# Altium schematic sheet Brightside_Telemetry_stm_rev2.SchDoc
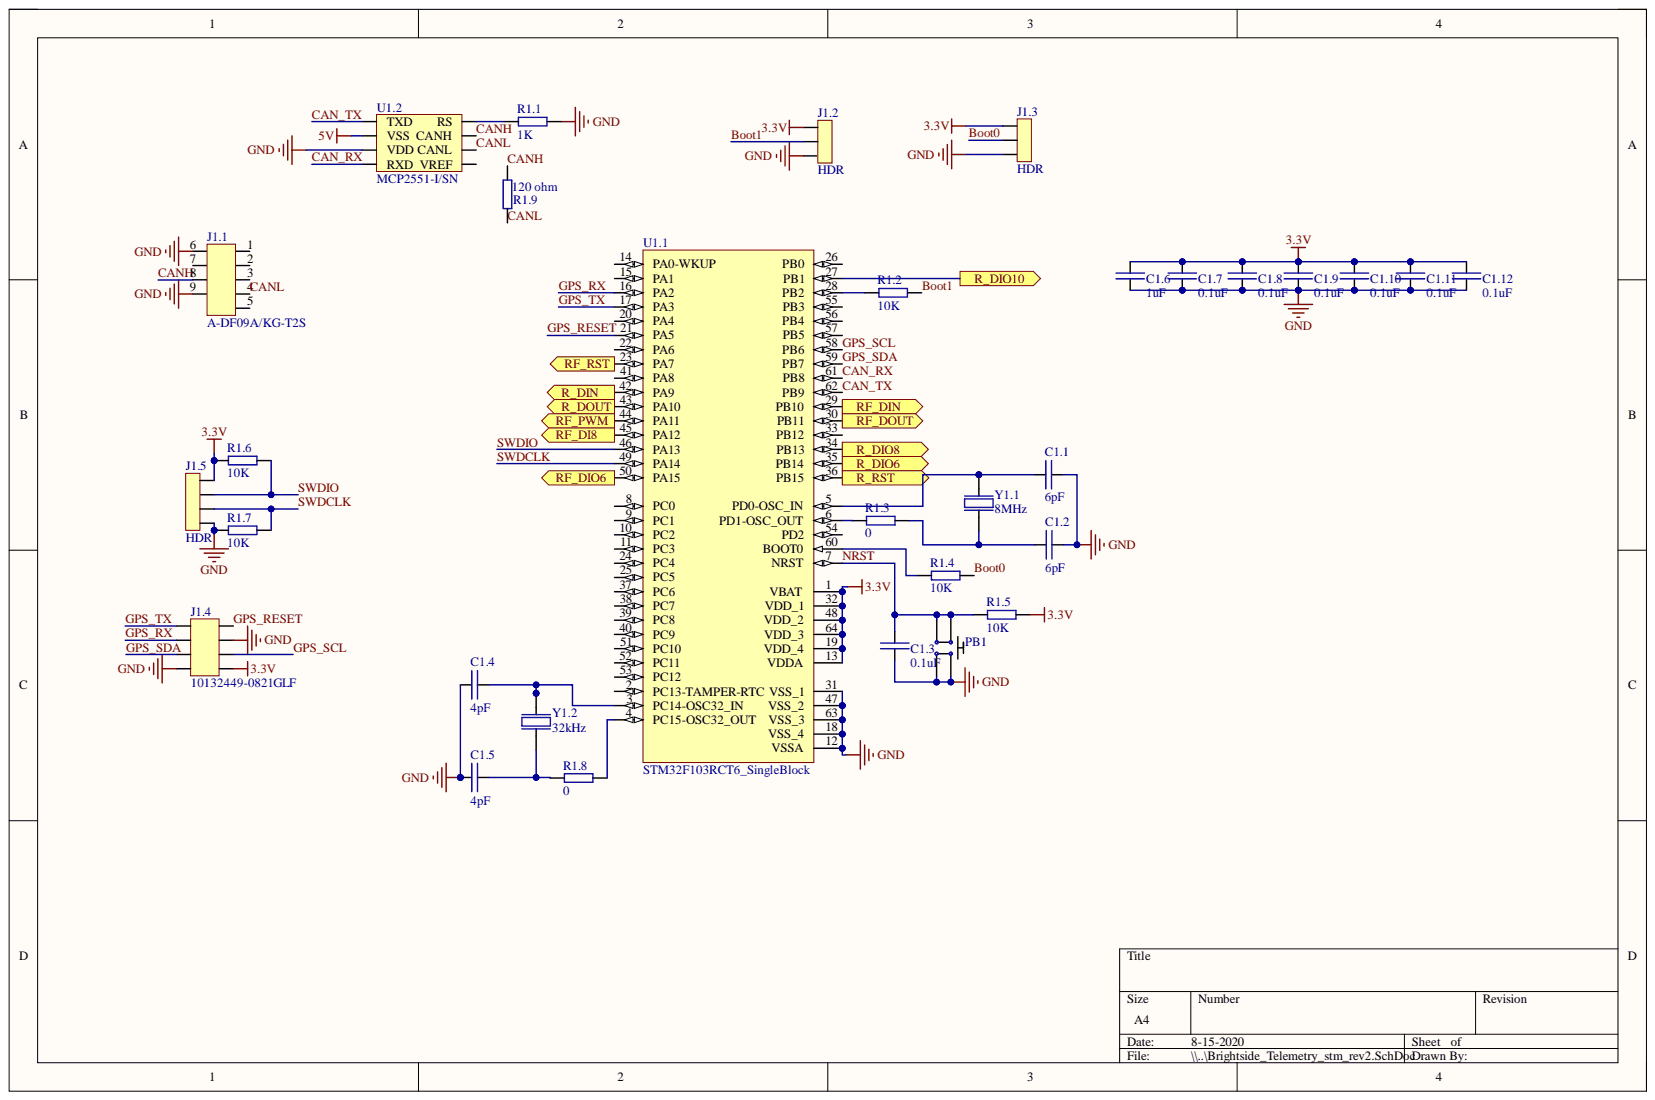
[diagram p0: Brightside_Telemetry_stm_rev2.SchDoc]

## Parts
C1.1  6pF  [0603]
C1.2  6pF  [0603]
C1.3  0.1uF  [C0805]
C1.4  4pF  [0603]
C1.5  4pF  [0603]
C1.6  1uF  [1206]
C1.7  0.1uF  [C0805]
C1.8  0.1uF  [C0805]
C1.9  0.1uF  [C0805]
C1.10  0.1uF  [C0805]
C1.11  0.1uF  [C0805]
C1.12  0.1uF  [C0805]
J1.1  A-DF09A/KG-T2S  [DB9 (Female)]
J1.2  HDR  [0.1" Header 3pos]
J1.3  HDR  [0.1" Header 3pos]
J1.4  10132449-0821GLF  [Micro-Fit 3.0 8pos]
J1.5  HDR  [0.1" Header 4pos]
PB1  P12961SCT-ND  [P12961SCT-ND]  (value not drawn)
R1.1  1K  [R0805]
R1.2  10K  [R0805]
R1.3  0  [0805]
R1.4  10K  [R0805]
R1.5  10K  [R0805]
R1.6  10K  [R0805]
R1.7  10K  [R0805]
R1.8  0  [0805]
R1.9  120 ohm  [R0805]
U1.1  STM32F103RCT6_SingleBlock  [64-LQFP]
U1.2  MCP2551-I/SN  Operating Supply Voltage: 5V  [8-SOIC]
Y1.1  8MHz  [NX8045GB-8.000000MHZ]
Y1.2  32kHz  [FC-135 32.7680KA-AG]

## Ports
R_DIN, R_DIO6, R_DIO8, R_DIO10, R_DOUT, R_RST, RF_DI8, RF_DIN, RF_DIO6, RF_DOUT, RF_PWM, RF_RST

## Nets
3.3V: C1.6.1 C1.7.2 C1.8.2 C1.9.2 C1.10.2 C1.11.2 C1.12.2 J1.2.1 J1.3.1 J1.4.4 J1.5.4 R1.5.2 R1.6.1 U1.1.1(VBAT) U1.1.13(VDDA) U1.1.19(VDD_4) U1.1.32(VDD_1) U1.1.48(VDD_2) U1.1.64(VDD_3)
5V: U1.2.2(VSS)
GND: C1.1.2 C1.2.2 C1.3.1 C1.4.1 C1.5.1 C1.6.2 C1.7.1 C1.8.1 C1.9.1 C1.10.1 C1.11.1 C1.12.1 J1.1.6 J1.1.9 J1.2.3 J1.3.3 J1.4.2 J1.4.8 J1.5.1 PB1.2 PB1.4 R1.1.2 R1.7.1 U1.1.12(VSSA) U1.1.18(VSS_4) U1.1.31(VSS_1) U1.1.47(VSS_2) U1.1.63(VSS_3) U1.2.3(VDD)
Boot0: J1.3.2 R1.4.2
Boot1: J1.2.2 R1.2.2
CAN_RX: U1.1.61(PB8) U1.2.4(RXD)
CAN_TX: U1.1.62(PB9) U1.2.1(TXD)
CANH: J1.1.8 R1.9.2 U1.2.7(CANH)
CANL: J1.1.4 R1.9.1 U1.2.6(CANL)
GPS_RESET: J1.4.1 U1.1.21(PA5)
GPS_RX: J1.4.6 U1.1.16(PA2)
GPS_SCL: J1.4.3 U1.1.58(PB6)
GPS_SDA: J1.4.7 U1.1.59(PB7)
GPS_TX: J1.4.5 U1.1.17(PA3)
NRST: C1.3.2 PB1.1 PB1.3 R1.5.1 U1.1.7(NRST)
R_DIN: U1.1.42(PA9) ; port R_DIN
R_DIO6: U1.1.35(PB14) ; port R_DIO6
R_DIO8: U1.1.34(PB13) ; port R_DIO8
R_DIO10: U1.1.27(PB1) ; port R_DIO10
R_DOUT: U1.1.43(PA10) ; port R_DOUT
R_RST: U1.1.36(PB15) ; port R_RST
RF_DI8: U1.1.45(PA12) ; port RF_DI8
RF_DIN: U1.1.29(PB10) ; port RF_DIN
RF_DIO6: U1.1.50(PA15) ; port RF_DIO6
RF_DOUT: U1.1.30(PB11) ; port RF_DOUT
RF_PWM: U1.1.44(PA11) ; port RF_PWM
RF_RST: U1.1.23(PA7) ; port RF_RST
SWDCLK: J1.5.2 R1.7.2 U1.1.49(PA14)
SWDIO: J1.5.3 R1.6.2 U1.1.46(PA13)
NetC1.1_1: C1.1.1 U1.1.5(PD0-OSC_IN) Y1.1.2
NetC1.2_1: C1.2.1 R1.3.2 Y1.1.1
NetC1.4_2: C1.4.2 U1.1.3(PC14-OSC32_IN) Y1.2.1
NetC1.5_2: C1.5.2 R1.8.1 Y1.2.2
NetR1.1_1: R1.1.1 U1.2.8(RS)
NetR1.2_1: R1.2.1 U1.1.28(PB2)
NetR1.3_1: R1.3.1 U1.1.6(PD1-OSC_OUT)
NetR1.4_1: R1.4.1 U1.1.60(BOOT0)
NetR1.8_2: R1.8.2 U1.1.4(PC15-OSC32_OUT)

Not connected: J1.1 1 2 3 5 7; U1.1 2(PC13-TAMPER-RTC) 8(PC0) 9(PC1) 10(PC2) 11(PC3) 14(PA0-WKUP) 15(PA1) 20(PA4) 22(PA6) 24(PC4) 25(PC5) 26(PB0) 33(PB12) 37(PC6) 38(PC7) 39(PC8) 40(PC9) 41(PA8) 51(PC10) 52(PC11) 53(PC12) 54(PD2) 55(PB3) 56(PB4) 57(PB5); U1.2 5(VREF)

## Library data of the parts
C1.1 C1.2 = CC0603DRNPO9BN6R0: CAP CER 6PF 50V C0G/NPO 0603; Manufacturer: Yageo; Dielectric: C0G; Capacitance: 6pF; Case Code (Imperial): 0603; Case Code (Metric): 1608; Case/Package: 0603; Category: Ceramic Capacitors; Component Kind: Standard; ComponentLink1Description: https://datasheet.ciiva.com/145/mlccorderingcode-145158.pdf?src-supplier=Digi-Key; ComponentLink1URL: https://datasheet.ciiva.com/145/mlccorderingcode-145158.pdf?src-supplier=Digi-Key; ComponentLink2Description: https://datasheet.ciiva.com/145/mlccorderingcode-145159.pdf?src-supplier=Digi-Key; ComponentLink2URL: https://datasheet.ciiva.com/145/mlccorderingcode-145159.pdf?src-supplier=Digi-Key; ComponentLink3Description: https://datasheet.ciiva.com/145/mlccorderingcode-145160.pdf?src-supplier=Digi-Key; ComponentLink3URL: https://datasheet.ciiva.com/145/mlccorderingcode-145160.pdf?src-supplier=Digi-Key; Composition: Ceramic; Depth: 0.8mm; Lead Free: Lead Free; Length: 1.6mm; Library Name: Capacitors.SchLib; Manufacturer Part Number: CC0603DRNPO9BN6R0; Max Operating Temperature: 125°C; Min Operating Temperature: -55°C; Mount: Surface Mount; Package Quantity: 1; Packaging: Tape and Reel; RoHS: Yes; Stock 1: 25117; Supplier 1: Digi-Key; Supplier Part Number 1: 311-1055-1-ND; Termination: SMD/SMT; Thickness: 0.035inch; Voltage Rating: 50V; Voltage Rating (DC): 50V; Weight: 7.1E-05oz; Width: 0.8mm
C1.3 C1.7-C1.12 = CL21B104KBCWPNC: CAP CER 0.1UF 50V X7R 0805; Manufacturer: Samsung; Capacitance: 100nF; Tolerance: 10%; Case Code (Imperial): 0805; Case Code (Metric): 2012; Case/Package: 0805; Category: Capacitors; Component Kind: Standard; Features: Soft Termination; Length: 0.079inch; Library Name: Capacitors.SchLib; Manufacturer Part Number: CL21B104KBCWPNC; Max Operating Temperature: 125°C; Min Operating Temperature: -55°C; Mount: Surface Mount; Packaging: Tape and Reel; Pricing 1: 1 = 0.10000, 10 = 0.06700, 100 = 0.02990, 500 = 0.02138, 1000 = 0.01680 (USD); Ratings: AEC-Q200; RoHS: Yes; Stock 1: 6545; Supplier 1: Digi-Key; Supplier Part Number 1: 1276-6557-1-ND; Thickness: 0.053inch; Voltage Rating: 50V; Width: 0.049inch
C1.4 C1.5 = CC0603CRNPO9BN4R0: CAP CER 4PF 50V C0G/NPO 0603; Manufacturer: Yageo; Dielectric: C0G; Insulation Resistance: 10GR; Capacitance: 4pF; Case Code (Imperial): 0603; Case Code (Metric): 1608; Case/Package: 0603; Category: Ceramic Capacitors; Component Kind: Standard; ComponentLink1Description: https://datasheet.ciiva.com/145/mlccorderingcode-145161.pdf?src-supplier=Digi-Key; ComponentLink1URL: https://datasheet.ciiva.com/145/mlccorderingcode-145161.pdf?src-supplier=Digi-Key; ComponentLink2Description: https://datasheet.ciiva.com/145/mlccorderingcode-145162.pdf?src-supplier=Digi-Key; ComponentLink2URL: https://datasheet.ciiva.com/145/mlccorderingcode-145162.pdf?src-supplier=Digi-Key; ComponentLink3Description: https://datasheet.ciiva.com/145/mlccorderingcode-145163.pdf?src-supplier=Digi-Key; ComponentLink3URL: https://datasheet.ciiva.com/145/mlccorderingcode-145163.pdf?src-supplier=Digi-Key; Composition: Ceramic; Depth: 0.8mm; Lead Free: Lead Free; Length: 1.6mm; Library Name: Capacitors.SchLib; Manufacturer Part Number: CC0603CRNPO9BN4R0; Max Operating Temperature: 125°C; Min Operating Temperature: -55°C; Mount: Surface Mount; Number of Pins: 2; Package Quantity: 1; Packaging: Tape and Reel; RoHS: Yes; Stock 1: 1954; Supplier 1: Digi-Key; Supplier Part Number 1: 311-1053-1-ND; Termination: SMD/SMT; Thickness: 0.035inch; Voltage Rating: 50V; Voltage Rating (DC): 50V; Weight: 7.1E-05oz; Width: 0.8mm
C1.6 = 885012208036: CAP CER 1UF 16V X7R 1206; Manufacturer: Wurth Electronics; Tolerance: 10%; Capacitance: 1uF; Case Code (Imperial): 1206; Case Code (Metric): 3216; Case/Package: 1206; Category: Ceramic Capacitors; Component Kind: Standard; ComponentLink1Description: http://katalog.we-online.de/pbs/datasheet/885012208036.pdf?src-supplier=Digi-Key; ComponentLink1URL: http://katalog.we-online.de/pbs/datasheet/885012208036.pdf?src-supplier=Digi-Key; ComponentLink2Description: https://katalog.we-online.de/pbs/datasheet/885012208036.pdf?src-supplier=Digi-Key; ComponentLink2URL: https://katalog.we-online.de/pbs/datasheet/885012208036.pdf?src-supplier=Digi-Key; Length: 0.126inch; Library Name: Capacitors.SchLib; Manufacturer Part Number: 885012208036; Max Operating Temperature: 125°C; Min Operating Temperature: -55°C; Mount: Surface Mount; Packaging: Tape and Reel; RoHS: Yes; Stock 1: 2993; Supplier 1: Digi-Key; Supplier Part Number 1: 732-7692-1-ND; Thickness: 0.051inch; Voltage Rating: 16V; Width: 0.063inch
J1.1 = A-DF09A/KG-T2S: FEMALE DB9 D-SUBMINIATURE CONNECTOR, CURRENT RATING 3A, VOLTAGE RATING 250VAC; Manufacturer: Assmann; Connector Type: D-Sub,Receptacle,Signal; Max Supply Voltage (AC): 250V; Number of Ports: 1; Number of Positions: 9; Category: D-Sub Connectors; Color: Black; Component Kind: Standard; ComponentLink1Description: https://datasheet.ciiva.com/26927/getdatasheetpartid-755627-26927441.pdf?src-supplier=Verical; ComponentLink1URL: https://datasheet.ciiva.com/26927/getdatasheetpartid-755627-26927441.pdf?src-supplier=Verical; Contact Material: Brass; Contact Plating: Gold; Current Rating: 3A; Depth: 18.6mm; Housing Material: PBT; Lead Free: Lead Free; Length: 30.81mm; Library Name: Connectors.SchLib; Manufacturer Part Number: A-DF09A/KG-T2S; Max Operating Temperature: 125°C; Min Operating Temperature: -55°C; Mount: Through Hole; Number of Rows: 2; Number of Terminals: 9; Orientation: Right Angle; Package Quantity: 90; Packaging: Tray; Radiation Hardening: No; RoHS: Yes; Stock 1: 18258; Supplier 1: Digi-Key; Supplier Part Number 1: AE10921-ND; Termination: Solder
J1.2 J1.3 = 0.1" Header 3pos: Component Kind: Standard; Library Name: Connectors.SchLib
J1.4 = 10132449-0821GLF: Micro-fit 3.0 8pos right-angle; Manufacturer: Molex; Manufacturer Part Number: 43045-0800; Approvals: UL,CSA,TUV; Contact Resistance: 10mR; Current Rating: 5A; Gender: Male; Glow Wire Compliant: Compliant; Insulation Resistance: 1GR; Max Voltage Rating (DC): 250.25kV; Number of Circuits: 8; Pitch: 3mm; Voltage Rating: 600V; Voltage Rating (AC): 250V; Case/Package: SMC; Category: Headers and Wire Housings; Color: Black; Component Kind: Standard; ComponentLink1Description: https://datasheet.ciiva.com/5158/0430450800-pcb-headers-142625-5158817.pdf?src-supplier=Mouser; ComponentLink1URL: https://datasheet.ciiva.com/5158/0430450800-pcb-headers-142625-5158817.pdf?src-supplier=Mouser; ComponentLink2Description: https://datasheet.ciiva.com/7683/27645-7683683.pdf?src-supplier=Element14; ComponentLink2URL: https://datasheet.ciiva.com/7683/27645-7683683.pdf?src-supplier=Element14; ComponentLink3Description: https://datasheet.ciiva.com/11751/0900766b80027348-11751142.pdf?src-supplier=RSComponents; ComponentLink3URL: https://datasheet.ciiva.com/11751/0900766b80027348-11751142.pdf?src-supplier=RSComponents; ComponentLink4Description: https://datasheet.ciiva.com/30198/267770430450800-pcb-headers-30198875.pdf?src-supplier=Onlinecomponents.com; ComponentLink4URL: https://datasheet.ciiva.com/30198/267770430450800-pcb-headers-30198875.pdf?src-supplier=Onlinecomponents.com; ComponentLink5Description: https://datasheet.ciiva.com/19462/883536-19462164.pdf?src-supplier=Jameco; ComponentLink5URL: https://datasheet.ciiva.com/19462/883536-19462164.pdf?src-supplier=Jameco; ComponentLink6Description: https://datasheet.ciiva.com/14155/04402310-14155206.pdf?src-supplier=Distrelec; ComponentLink6URL: https://datasheet.ciiva.com/14155/04402310-14155206.pdf?src-supplier=Distrelec; ComponentLink7Description: https://datasheet.ciiva.com/16657/0430450800-pcb-headers-16657964.pdf?src-supplier=Avnet+Europe; ComponentLink7URL: https://datasheet.ciiva.com/16657/0430450800-pcb-headers-16657964.pdf?src-supplier=Avnet+Europe; ComponentLink8Description: https://datasheet.ciiva.com/10087/0430450800-pcb-headers-10087853.pdf?src-supplier=Avnet; ComponentLink8URL: https://datasheet.ciiva.com/10087/0430450800-pcb-headers-10087853.pdf?src-supplier=Avnet; ComponentLink9Description: https://datasheet.ciiva.com/1443/1850016-1443537.pdf?src-supplier=Element14; ComponentLink9URL: https://datasheet.ciiva.com/1443/1850016-1443537.pdf?src-supplier=Element14; Contact Material: Brass,Tin; Contact Plating: Tin; Depth: 12.2mm; Diameter: 3mm; Flammability Rating: UL94 V-0; Halogen Free: Low Halogen; Height: 8.77mm; Housing Color: Black; Housing Material: Thermoplastic; Lead Free: Lead Free; Length: 16.15mm; Library Name: Connectors.SchLib; Material: Polyester; Max Current Rating: 5A; Max Operating Temperature: 105°C; Min Operating Temperature: -40°C; Mount: Through Hole; Number of Contacts: 8; Number of Poles: 8; Number of Positions: 8; Number of Rows: 2; Orientation: Right Angle; Package Quantity: 1440; Packaging: Tray; Radiation Hardening: No; REACH SVHC: Unknown; RoHS: Yes; Row Spacing: 3mm; Stock 1: 7880; Supplier 1: Digi-Key; Supplier Part Number 1: WM1816-ND; Termination: Solder; Voltage Rating (DC): 250V; Working Voltage: 250V
J1.5 = 0.1" Header 4pos: Component Kind: Standard; Library Name: Connectors.SchLib
PB1 = P12961SCT-ND: SWITCH TACTILE SPST-NO 0.02A 15V; Manufacturer 1: Panasonic; Manufacturer Part Number 1: EVQ-Q2Y03W; Actuator Length: 1.3mm; Actuator Type: Standard; Contact Current Rating: 20mA; Contact Resistance: 100mR; Electrical Life: 100000Cycles; Illumination Type: Non-Illuminated,Illuminated; Number of Poles: 1; Operating Force: 3.5N; Switch Function: Off-Mom; Switch Travel: 0.25mm; Throw Configuration: SPST; Voltage Rating (AC): 250V; Case/Package: SMD/SMT; Color: White; Component Kind: Standard; ComponentLink1Description: https://datasheet.ciiva.com/25603/0900766b810ad3e5-25603840.pdf?src-supplier=RSComponents; ComponentLink1URL: https://datasheet.ciiva.com/25603/0900766b810ad3e5-25603840.pdf?src-supplier=RSComponents; ComponentLink2Description: https://datasheet.ciiva.com/2901/atk0000ce28-2901284.pdf?src-supplier=Digi-Key; ComponentLink2URL: https://datasheet.ciiva.com/2901/atk0000ce28-2901284.pdf?src-supplier=Digi-Key; ComponentLink3Description: https://datasheet.ciiva.com/2901/atk0000ce28-2901285.pdf?src-supplier=Digi-Key; ComponentLink3URL: https://datasheet.ciiva.com/2901/atk0000ce28-2901285.pdf?src-supplier=Digi-Key; ComponentLink4Description: https://datasheet.ciiva.com/2901/atk0000ce28-2901286.pdf?src-supplier=Digi-Key; ComponentLink4URL: https://datasheet.ciiva.com/2901/atk0000ce28-2901286.pdf?src-supplier=Digi-Key; ComponentLink5Description: https://datasheet.ciiva.com/30127/8atk0000ce28-30127814.pdf?src-supplier=Onlinecomponents.com; ComponentLink5URL: https://datasheet.ciiva.com/30127/8atk0000ce28-30127814.pdf?src-supplier=Onlinecomponents.com; ComponentLink6Description: https://datasheet.ciiva.com/8165/atk0000ce28-8165191.pdf?src-supplier=Avnet; ComponentLink6URL: https://datasheet.ciiva.com/8165/atk0000ce28-8165191.pdf?src-supplier=Avnet; ComponentLink7Description: https://datasheet.ciiva.com/17099/70270561-17099386.pdf?src-supplier=Allied+Electronics; ComponentLink7URL: https://datasheet.ciiva.com/17099/70270561-17099386.pdf?src-supplier=Allied+Electronics; ComponentLink8Description: https://datasheet.ciiva.com/5685/28111-5685339.pdf?src-supplier=Farnell; ComponentLink8URL: https://datasheet.ciiva.com/5685/28111-5685339.pdf?src-supplier=Farnell; ComponentLink9Description: https://datasheet.ciiva.com/16438/atk0000ce28-16438079.pdf?src-supplier=Future+Electronics; ComponentLink9URL: https://datasheet.ciiva.com/16438/atk0000ce28-16438079.pdf?src-supplier=Future+Electronics; Current Rating: 20mA; Depth: 6.6mm; Height: 3.1mm; Lead Free: Lead Free; Length: 6mm; Max Current Rating: 20mA; Max Operating Temperature: 85°C; Max Voltage Rating (DC): 15V; Mechanical Life: 100000Cycles; Min Operating Temperature: -40°C; Mount: Surface Mount; Orientation: Straight; Package Quantity: 1; Packaging: Tape and Reel; Pricing 1: 1=0.30000, 10=0.28600, 25=0.27520, 50=0.26520, 100=0.25490, 250=0.23452, 500=0.20394, 1000=0.17335 (USD); Radiation Hardening: No; REACH SVHC: Unknown; RoHS: Yes; Stock 1: 209620; Supplier 1: Digi-Key; Supplier Part Number 1: P12961SDKR-ND; Termination: SMD/SMT; Thickness: 1.8mm; Voltage Rating (DC): 15V; Width: 6mm; Library Name: Indication & Control.SchLib
R1.1 = ERJ6ENF1001V: RES SMD 1K OHM 1% 1/8W 0805; Manufacturer: Panasonic; Max Power Dissipation: 125mW; Resistance: 1kR; Temperature Coefficient: 100ppm/°C; Voltage Rating: 150V; Case Code (Imperial): 0805; Case Code (Metric): 2012; Case/Package: 0805; Category: Resistors; Component Kind: Standard; ComponentLink1Description: https://datasheet.ciiva.com/34303/1988962-34303619.pdf?src-supplier=Jameco; ComponentLink1URL: https://datasheet.ciiva.com/34303/1988962-34303619.pdf?src-supplier=Jameco; ComponentLink2Description: https://datasheet.ciiva.com/11808/0900766b80f80784-11808500.pdf?src-supplier=RSComponents; ComponentLink2URL: https://datasheet.ciiva.com/11808/0900766b80f80784-11808500.pdf?src-supplier=RSComponents; ComponentLink3Description: https://datasheet.ciiva.com/28532/426256-28532134.pdf?src-supplier=Element14; ComponentLink3URL: https://datasheet.ciiva.com/28532/426256-28532134.pdf?src-supplier=Element14; ComponentLink4Description: https://datasheet.ciiva.com/30051/aoa0000ce2-30051974.pdf?src-supplier=Onlinecomponents.com; ComponentLink4URL: https://datasheet.ciiva.com/30051/aoa0000ce2-30051974.pdf?src-supplier=Onlinecomponents.com; ComponentLink5Description: https://datasheet.ciiva.com/16774/70260748-16774249.pdf?src-supplier=Allied+Electronics; ComponentLink5URL: https://datasheet.ciiva.com/16774/70260748-16774249.pdf?src-supplier=Allied+Electronics; ComponentLink6Description: https://datasheet.ciiva.com/46373/1678782-46373337.pdf?src-supplier=Element14; ComponentLink6URL: https://datasheet.ciiva.com/46373/1678782-46373337.pdf?src-supplier=Element14; ComponentLink7Description: https://datasheet.ciiva.com/46373/1678782-46373338.pdf?src-supplier=Element14; ComponentLink7URL: https://datasheet.ciiva.com/46373/1678782-46373338.pdf?src-supplier=Element14; ComponentLink8Description: https://datasheet.ciiva.com/48532/aoa0000ce2-48532156.pdf?src-supplier=Avnet; ComponentLink8URL: https://datasheet.ciiva.com/48532/aoa0000ce2-48532156.pdf?src-supplier=Avnet; ComponentLink9Description: https://datasheet.ciiva.com/7942/426256-7942429.pdf?src-supplier=Element14; ComponentLink9URL: https://datasheet.ciiva.com/7942/426256-7942429.pdf?src-supplier=Element14; ComponentLink10Description: https://datasheet.ciiva.com/16199/aoa0000ce2-16199262.pdf?src-supplier=Future+Electronics; ComponentLink10URL: https://datasheet.ciiva.com/16199/aoa0000ce2-16199262.pdf?src-supplier=Future+Electronics; ComponentLink11Description: https://datasheet.ciiva.com/9117/aoa0000ce2-9117391.pdf?src-supplier=Avnet; ComponentLink11URL: https://datasheet.ciiva.com/9117/aoa0000ce2-9117391.pdf?src-supplier=Avnet; ComponentLink12Description: https://datasheet.ciiva.com/16523/aoa0000ce2-16523855.pdf?src-supplier=Avnet+Asia; ComponentLink12URL: https://datasheet.ciiva.com/16523/aoa0000ce2-16523855.pdf?src-supplier=Avnet+Asia; Composition: Thick Film; Contact Plating: Tin; Depth: 1.25mm; Features: Automotive AEC-Q200; Height: 0.6mm; Lead Free: Lead Free; Length: 2mm; Library Name: Resistors.SchLib; Manufacturer Part Number: ERJ6ENF1001V; Max Operating Temperature: 155°C; Military Standard: Not; Min Operating Temperature: -55°C; Mount: Surface Mount; Number of Pins: 2; Number of Terminations: 2; Package Quantity: 1; Packaging: Tape and Reel; Power Rating: 125mW; Pricing 1: 1 = 0.100000, 10 = 0.081000, 100 = 0.031100, 1000 = 0.013670, 2500 = 0.011810 (USD); Radiation Hardening: No; REACH SVHC: No SVHC; RoHS: Yes; Stock 1: 946321; Supplier 1: Digi-Key; Supplier Part Number 1: P1.00KCCT-ND; Termination: SMD/SMT; Tolerance: 1%; Voltage Rating (DC): 150V; Width: 0.049inch
R1.2 R1.4-R1.7 = ERJ6ENF1002V: RES SMD 10K OHM 1% 1/8W 0805; Manufacturer: Yageo; Manufacturer Part Number: ERJ-6ENF1002V; Power Rating: 125mW; Resistance: 10000R; Temperature Coefficient: 100ppm/°C; Voltage Rating (DC): 150V; Case/Package: 0805; Category: Resistors; Component Kind: Standard; ComponentLink1Description: https://datasheet.ciiva.com/27056/2078477-27056611.pdf?src-supplier=Jameco; ComponentLink1URL: https://datasheet.ciiva.com/27056/2078477-27056611.pdf?src-supplier=Jameco; Depth: 1.25mm; Height: 0.5mm; Lead Free: Lead Free; Length: 2mm; Library Name: Resistors.SchLib; Max Operating Temperature: 155°C; Min Operating Temperature: -55°C; Mount: Surface Mount; Number of Pins: 2; Packaging: Tape and Reel; Pricing 1: 1 = 0.100000, 10 = 0.081000, 100 = 0.031100, 1000 = 0.013670, 2500 = 0.011810 (USD); REACH SVHC: No SVHC; RoHS: No; Stock 1: 3342401; Supplier 1: Digi-Key; Supplier Part Number 1: P10.0KCCT-ND; Tolerance: 1%; Width: 1.25mm
R1.3 R1.8 = RMCF0805ZT0R00: STACKPOLE ELECTRONICS - RMCF0805ZT0R00. - RES THKFLM 0805 0 OHM JUMPER 1/8W 200PPM/ C SMD ROHS COMPLIANT: YES; Max Power Dissipation: 125mW; Resistance: 0mR; Case Code (Imperial): 0805; Case Code (Metric): 2012; Case/Package: 0805; Category: Chip SMD Resistors; Component Kind: Standard; ComponentLink1Description: https://datasheet.ciiva.com/15193/getdatasheetpartid-360417-15193658.pdf?src-supplier=Verical; ComponentLink1URL: https://datasheet.ciiva.com/15193/getdatasheetpartid-360417-15193658.pdf?src-supplier=Verical; Composition: Thick Film; Contact Plating: Tin,Matte; Depth: 1.25mm; Features: Automotive AEC-Q200; Height: 0.026inch; Lead Free: Lead Free; Length: 2mm; Library Name: Resistors.SchLib; Manufacturer: Stackpole Electronics; Manufacturer Part Number: RMCF0805ZT0R00; Max Operating Temperature: 155°C; Military Standard: Not; Min Operating Temperature: -55°C; Mount: Surface Mount; Number of Terminations: 2; Packaging: Tape and Reel; Power Rating: 125mW; Radiation Hardening: No; RoHS: Yes; Stock 1: 14305; Supplier 1: Digi-Key; Supplier Part Number 1: RMCF0805ZT0R00CT-ND; Width: 0.049inch
R1.9 = ERJ6ENV1200V: RES SMD 120 OHM 1% 1/8W 0805; Component Kind: Standard; ComponentLink1Description: https://datasheet.ciiva.com/11821/0900766b80f80784-11821533.pdf?src-supplier=RSComponents; ComponentLink1URL: https://datasheet.ciiva.com/11821/0900766b80f80784-11821533.pdf?src-supplier=RSComponents; Library Name: Resistors.SchLib; Supplier 1: Digi-Key; Supplier Part Number 1: P120CCT-ND
U1.1 = STM32F103RCT6_SingleBlock: ARM® Cortex®-M3 STM32F1 Microcontroller IC 32-Bit 72MHz 256KB (256K x 8) FLASH; Component Kind: Standard; Library Name: ICs.SchLib; Supplier 1: Digi-Key; Supplier Part Number 1: 497-8920-ND
U1.2 = MCP2551-I/SN: CAN TRANSCEIVER; Manufacturer: Microchip; Access Time: 900ns; Body Material: Steel; Connector Type: D-Sub,Plug,Signal; Contact Resistance: 10mR; Current Rating: 5A; Density: 256Kb; ESR (Equivalent Series Resistance): 140R; Frequency: 1MHz; Frequency Stability: 30ppm; Frequency Tolerance: 20ppm; Gender: Male; Glow Wire Compliant: Compliant; Insulation Resistance: 1GR; Interface: SPI; Load Capacitance: 18pF; Max Frequency: 400kHz; Max Supply Voltage: 5.5V; Memory Type: EEPROM; Min Supply Voltage: 2.7V; Nominal Supply Current: 2.5mA; Number of Circuits: 2; Number of Contacts: 9; Pitch: 2.84mm; Row Spacing: 0.1inch; Series Resistance: 500R; Voltage Rating: 125V; Voltage Rating (AC): 250V; Case/Package: MSOP; Category: Interface ICs; Color: Black; Component Kind: Standard; ComponentLink1Description: https://datasheet.ciiva.com/8084/jp011797-8084592.pdf?src-supplier=Avnet; ComponentLink1URL: https://datasheet.ciiva.com/8084/jp011797-8084592.pdf?src-supplier=Avnet; ComponentLink2Description: https://datasheet.ciiva.com/27056/2040583-27056423.pdf?src-supplier=Jameco; ComponentLink2URL: https://datasheet.ciiva.com/27056/2040583-27056423.pdf?src-supplier=Jameco; ComponentLink3Description: https://datasheet.ciiva.com/1495/21667f-1495089.pdf?src-supplier=Element14; ComponentLink3URL: https://datasheet.ciiva.com/1495/21667f-1495089.pdf?src-supplier=Element14; ComponentLink4Description: https://datasheet.ciiva.com/1700/21667f-1700023.pdf?src-supplier=Digi-Key; ComponentLink4URL: https://datasheet.ciiva.com/1700/21667f-1700023.pdf?src-supplier=Digi-Key; ComponentLink5Description: https://datasheet.ciiva.com/14152/eb644223-644224-e-14152540.pdf?src-supplier=Distrelec; ComponentLink5URL: https://datasheet.ciiva.com/14152/eb644223-644224-e-14152540.pdf?src-supplier=Distrelec; ComponentLink6Description: https://datasheet.ciiva.com/17649/jp011797-17649039.pdf?src-supplier=Avnet+Europe; ComponentLink6URL: https://datasheet.ciiva.com/17649/jp011797-17649039.pdf?src-supplier=Avnet+Europe; ComponentLink7Description: https://datasheet.ciiva.com/655/171-yyy-113ryy1-655574.pdf?src-supplier=Digi-Key; ComponentLink7URL: https://datasheet.ciiva.com/655/171-yyy-113ryy1-655574.pdf?src-supplier=Digi-Key; ComponentLink8Description: https://datasheet.ciiva.com/5118/171-yyy-113ryy1-5118968.pdf?src-supplier=Mouser; ComponentLink8URL: https://datasheet.ciiva.com/5118/171-yyy-113ryy1-5118968.pdf?src-supplier=Mouser; ComponentLink9Description: https://datasheet.ciiva.com/16376/171-yyy-113ryy1-16376504.pdf?src-supplier=Future+Electronics; ComponentLink9URL: https://datasheet.ciiva.com/16376/171-yyy-113ryy1-16376504.pdf?src-supplier=Future+Electronics; ComponentLink10Description: https://datasheet.ciiva.com/12669/6180xx231121-12669218.pdf?src-supplier=Mouser; ComponentLink10URL: https://datasheet.ciiva.com/12669/6180xx231121-12669218.pdf?src-supplier=Mouser; ComponentLink11Description: https://datasheet.ciiva.com/1426/1442189-1426428.pdf?src-supplier=Element14; ComponentLink11URL: https://datasheet.ciiva.com/1426/1442189-1426428.pdf?src-supplier=Element14; ComponentLink12Description: https://datasheet.ciiva.com/12669/6180xx231221-12669204.pdf?src-supplier=Mouser; ComponentLink12URL: https://datasheet.ciiva.com/12669/6180xx231221-12669204.pdf?src-supplier=Mouser; ComponentLink13Description: https://datasheet.ciiva.com/25605/0900766b81191766-25605476.pdf?src-supplier=RSComponents; ComponentLink13URL: https://datasheet.ciiva.com/25605/0900766b81191766-25605476.pdf?src-supplier=RSComponents; ComponentLink14Description: https://datasheet.ciiva.com/1426/1442191-1426429.pdf?src-supplier=Element14; ComponentLink14URL: https://datasheet.ciiva.com/1426/1442191-1426429.pdf?src-supplier=Element14; ComponentLink15Description: https://datasheet.ciiva.com/22649/1730562-22649446.pdf?src-supplier=Element14; ComponentLink15URL: https://datasheet.ciiva.com/22649/1730562-22649446.pdf?src-supplier=Element14; ComponentLink16Description: https://datasheet.ciiva.com/22767/1730562-22767677.pdf?src-supplier=Farnell; ComponentLink16URL: https://datasheet.ciiva.com/22767/1730562-22767677.pdf?src-supplier=Farnell; ComponentLink17Description: https://datasheet.ciiva.com/15123/getdatasheetpartid-309492-15123357.pdf?src-supplier=Verical; ComponentLink17URL: https://datasheet.ciiva.com/15123/getdatasheetpartid-309492-15123357.pdf?src-supplier=Verical; ComponentLink18Description: https://datasheet.ciiva.com/41455/001185584-da-01-en-ic-eepr-256kbi-m24256-brmn6tp-soic-8-stm-41455201.pdf?src-supplier=Conrad; ComponentLink18URL: https://datasheet.ciiva.com/41455/001185584-da-01-en-ic-eepr-256kbi-m24256-brmn6tp-soic-8-stm-41455201.pdf?src-supplier=Conrad; ComponentLink19Description: https://datasheet.ciiva.com/1905/cd00001891-1905179.pdf?src-supplier=Digi-Key; ComponentLink19URL: https://datasheet.ciiva.com/1905/cd00001891-1905179.pdf?src-supplier=Digi-Key; ComponentLink20Description: https://datasheet.ciiva.com/1905/cd00001891-1905180.pdf?src-supplier=Digi-Key; ComponentLink20URL: https://datasheet.ciiva.com/1905/cd00001891-1905180.pdf?src-supplier=Digi-Key; ComponentLink21Description: https://datasheet.ciiva.com/1905/cd00001891-1905181.pdf?src-supplier=Digi-Key; ComponentLink21URL: https://datasheet.ciiva.com/1905/cd00001891-1905181.pdf?src-supplier=Digi-Key; ComponentLink22Description: https://datasheet.ciiva.com/14155/04402066-14155224.pdf?src-supplier=Distrelec; ComponentLink22URL: https://datasheet.ciiva.com/14155/04402066-14155224.pdf?src-supplier=Distrelec; ComponentLink23Description: https://datasheet.ciiva.com/5158/0436500200-pcb-headers-148844-5158523.pdf?src-supplier=Mouser; ComponentLink23URL: https://datasheet.ciiva.com/5158/0436500200-pcb-headers-148844-5158523.pdf?src-supplier=Mouser; ComponentLink24Description: https://datasheet.ciiva.com/11748/0900766b80d6135b-11748140.pdf?src-supplier=RSComponents; ComponentLink24URL: https://datasheet.ciiva.com/11748/0900766b80d6135b-11748140.pdf?src-supplier=RSComponents; ComponentLink25Description: https://datasheet.ciiva.com/22683/1709823-22683551.pdf?src-supplier=Element14; ComponentLink25URL: https://datasheet.ciiva.com/22683/1709823-22683551.pdf?src-supplier=Element14; ComponentLink26Description: https://datasheet.ciiva.com/30161/48630436500200-pcb-headers-30161343.pdf?src-supplier=Onlinecomponents.com; ComponentLink26URL: https://datasheet.ciiva.com/30161/48630436500200-pcb-headers-30161343.pdf?src-supplier=Onlinecomponents.com; ComponentLink27Description: https://datasheet.ciiva.com/9432/0436500200-pcb-headers-9432849.pdf?src-supplier=Avnet; ComponentLink27URL: https://datasheet.ciiva.com/9432/0436500200-pcb-headers-9432849.pdf?src-supplier=Avnet; ComponentLink28Description: https://datasheet.ciiva.com/16089/1029720436500200-pcb-headers-16089218.pdf?src-supplier=Onlinecomponents.com; ComponentLink28URL: https://datasheet.ciiva.com/16089/1029720436500200-pcb-headers-16089218.pdf?src-supplier=Onlinecomponents.com; ComponentLink29Description: https://datasheet.ciiva.com/7920/1839562-7920756.pdf?src-supplier=Element14; ComponentLink29URL: https://datasheet.ciiva.com/7920/1839562-7920756.pdf?src-supplier=Element14; ComponentLink30Description: https://datasheet.ciiva.com/19462/884053-19462312.pdf?src-supplier=Jameco; ComponentLink30URL: https://datasheet.ciiva.com/19462/884053-19462312.pdf?src-supplier=Jameco; ComponentLink31Description: https://datasheet.ciiva.com/16654/0436500200-pcb-headers-16654958.pdf?src-supplier=Avnet+Europe; ComponentLink31URL: https://datasheet.ciiva.com/16654/0436500200-pcb-headers-16654958.pdf?src-supplier=Avnet+Europe; ComponentLink32Description: https://datasheet.ciiva.com/6904/3020-34-0300-00-6904731.pdf?src-supplier=Digi-Key; ComponentLink32URL: https://datasheet.ciiva.com/6904/3020-34-0300-00-6904731.pdf?src-supplier=Digi-Key; ComponentLink33Description: https://datasheet.ciiva.com/18485/3020-xx-0300-00-18485471.pdf?src-supplier=Digi-Key; ComponentLink33URL: https://datasheet.ciiva.com/18485/3020-xx-0300-00-18485471.pdf?src-supplier=Digi-Key; ComponentLink34Description: https://datasheet.ciiva.com/862/abm3-862465.pdf?src-supplier=Digi-Key; ComponentLink34URL: https://datasheet.ciiva.com/862/abm3-862465.pdf?src-supplier=Digi-Key; ComponentLink35Description: https://datasheet.ciiva.com/855/abs07-855948.pdf?src-supplier=Digi-Key; ComponentLink35URL: https://datasheet.ciiva.com/855/abs07-855948.pdf?src-supplier=Digi-Key; Composition: Ceramic; Contact Material: Brass; Contact Plating: Gold; Depth: 18.5mm; Flammability Rating: UL94 V-0; Halogen Free: Low Halogen; Height: 9.93mm; Housing Color: Black; Housing Material: Nylon; Lead Free: Lead Free; Length: 30.8mm; Library Name: ICs.SchLib; Manufacturer Part Number: MCP2551-I/SN; Material: Metal; Max Operating Temperature: 100°C; Memory Size: 256Kb; Min Operating Temperature: -50°C; Mount: Through Hole; Number of Pins: 16; Number of Positions: 9; Number of Rows: 2; Orientation: Right Angle; Package Quantity: 80; Packaging: Tray; Radiation Hardening: No; REACH SVHC: No SVHC; RoHS: Yes; Stock 1: 3363; Stock 2: 10320; Stock 3: 281; Stock 4: 49; Stock 5: 4671; Stock 6: 4627; Stock 7: 11258; Stock 8: 21730; Stock 9: 68218; Stock 10: 100673; Supplier 1: Digi-Key; Supplier 2: Digi-Key; Supplier 3: Digi-Key; Supplier 4: Digi-Key; Supplier 5: Digi-Key; Supplier 6: Digi-Key; Supplier 7: Digi-Key; Supplier 8: Digi-Key; Supplier 9: Digi-Key; Supplier 10: Digi-Key; Supplier Part Number 1: MCP2551-I/SN-ND; Supplier Part Number 2: 9209ME-ND; Supplier Part Number 3: 732-618009231121-ND; Supplier Part Number 4: 732-618009231221-ND; Supplier Part Number 5: LTC6820IMS#PBF-ND; Supplier Part Number 6: 497-6348-1-ND; Supplier Part Number 7: WM1860-ND; Supplier Part Number 8: 1175-1623-ND; Supplier Part Number 9: 535-10630-1-ND; Supplier Part Number 10: 535-9544-1-ND; Termination: Through Hole; Tolerance: 20ppm; Voltage Rating (DC): 250V; Width: 12.6mm; Working Voltage: 250V
Y1.1 = NX8045GB-8.000000MHZ: CRYSTAL 8.0000MHZ 8PF SMD; Manufacturer: NDK; ESR (Equivalent Series Resistance): 200R; Frequency Stability: 50ppm; Case/Package: SMD/SMT; Category: Crystals; Component Kind: Standard; ComponentLink1Description: https://datasheet.ciiva.com/856/nx8045gb-856752.pdf?src-supplier=Digi-Key; ComponentLink1URL: https://datasheet.ciiva.com/856/nx8045gb-856752.pdf?src-supplier=Digi-Key; ComponentLink2Description: https://datasheet.ciiva.com/856/nx8045gb-856753.pdf?src-supplier=Digi-Key; ComponentLink2URL: https://datasheet.ciiva.com/856/nx8045gb-856753.pdf?src-supplier=Digi-Key; ComponentLink3Description: https://datasheet.ciiva.com/856/nx8045gb-856754.pdf?src-supplier=Digi-Key; ComponentLink3URL: https://datasheet.ciiva.com/856/nx8045gb-856754.pdf?src-supplier=Digi-Key; Frequency: 8MHz; Frequency Tolerance: 50ppm; Height: 0.079inch; Lead Free: Exempt; Length: 0.315inch; Library Name: Crystals.SchLib; Load Capacitance: 8pF; Manufacturer Part Number: NX8045GB-8.000000MHZ; Max Operating Temperature: 70°C; Min Operating Temperature: -10°C; Mount: Surface Mount; Number of Pins: 2; Package Quantity: 1000; Packaging: Tape and Reel; RoHS: Yes; Stock 1: 116457; Supplier 1: Digi-Key; Supplier Part Number 1: 644-1018-1-ND; Width: 0.177inch
Y1.2 = FC-13532.7680KA-AG0: Crystals 32.768KHz 20ppm 7.0pF -40C +85C; Manufacturer: Epson; Capacitance: 7E-12F; ESR (Equivalent Series Resistance): 65kR; Load Capacitance: 7pF; Category: Uncategorized; Component Kind: Standard; ComponentLink1Description: http://www.mouser.com/ds/2/137/1699449-465441.pdf?src-supplier=Mouser; ComponentLink1URL: http://www.mouser.com/ds/2/137/1699449-465441.pdf?src-supplier=Mouser; Frequency: 32.768kHz; Frequency Stability: 20ppm; Height: 0.8mm; Length: 3.2mm; Library Name: Crystals.SchLib; Manufacturer Part Number: FC-13532.7680KA-AG0; Max Operating Temperature: 85°C; Min Operating Temperature: -40°C; Package Quantity: 1000; Packaging: Tape and Reel; RoHS: No; Supplier 1: Mouser; Supplier Part Number 1: 732-FC135-32.76KAAG0; Termination: SMD/SMT; Tolerance: 20ppm; Weight: 0.000564oz; Width: 1.5mm
